# Altium schematic sheet MMF2.SchDoc
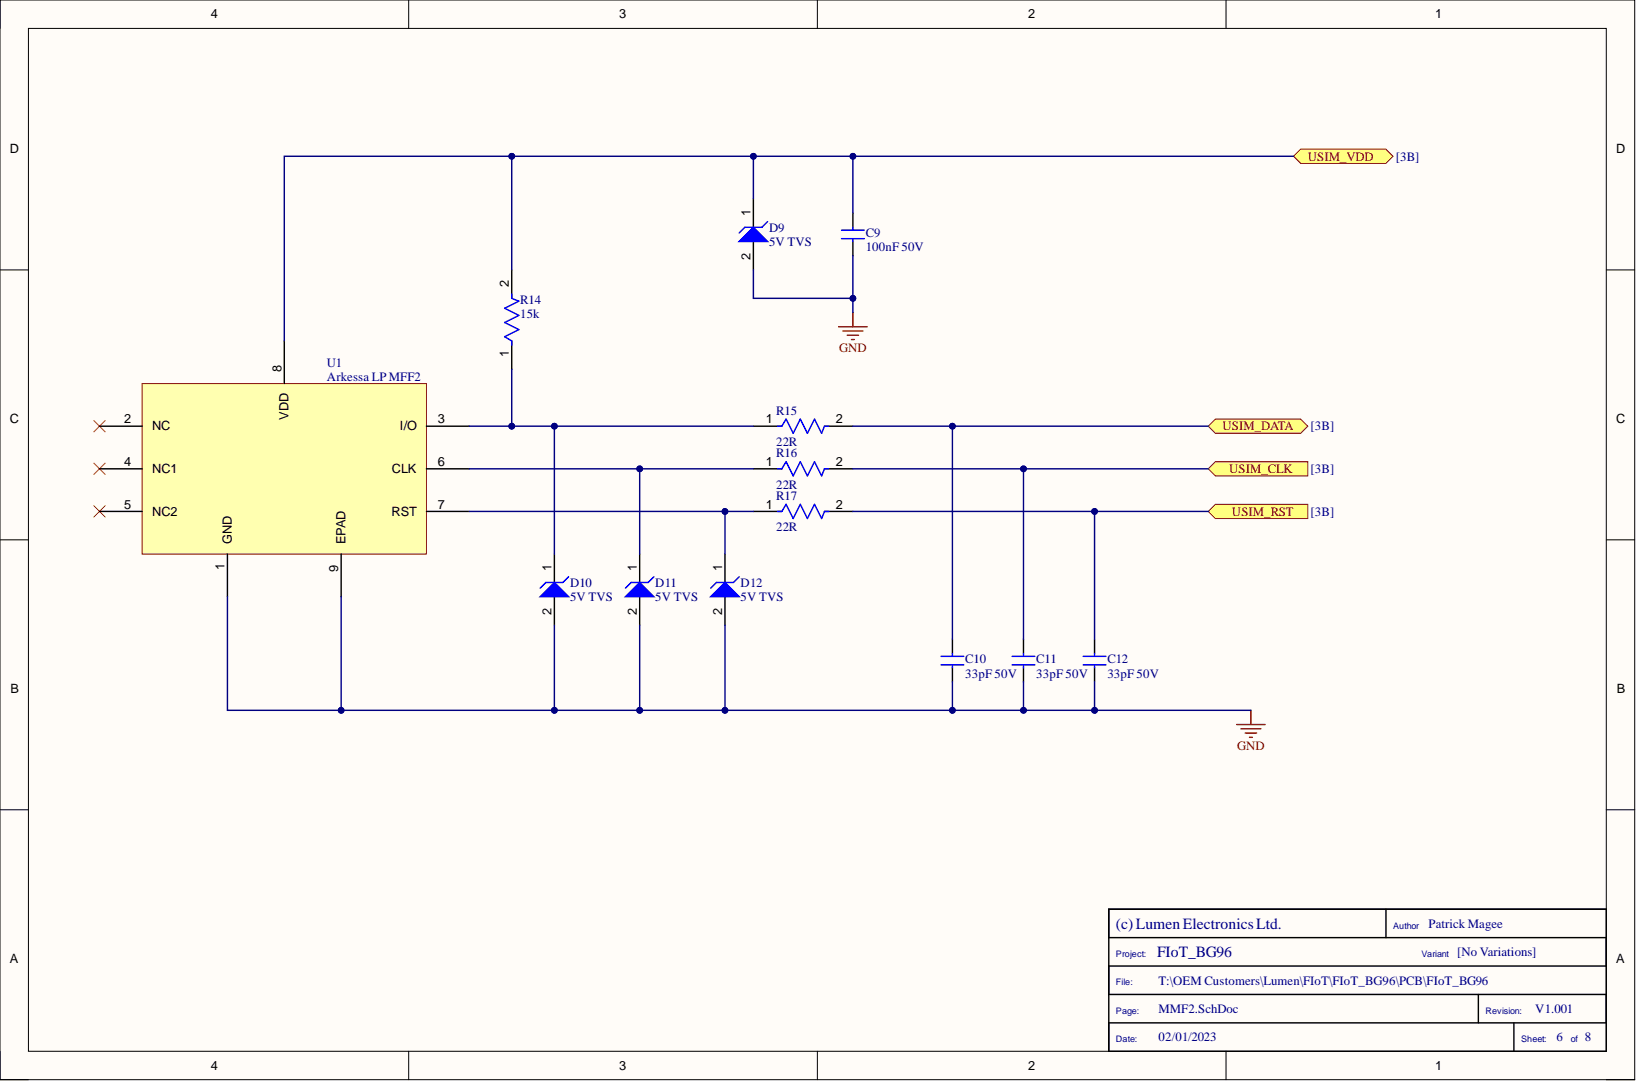
[diagram p0: MMF2.SchDoc]

## Parts
C9  value 100nF 50V  [CAPC1005X06N]  (hidden comment: CL05B104KB54PNC)
C10  value 33pF 50V  [CAPC1005X06N]  (hidden comment: GJM1555C1H330GB01D)
C11  value 33pF 50V  [CAPC1005X06N]  (hidden comment: GJM1555C1H330GB01D)
C12  value 33pF 50V  [CAPC1005X06N]  (hidden comment: GJM1555C1H330GB01D)
D9  value 5V TVS  [ONSC-SOD-923-2-514AA-01_V]  (hidden comment: ESD9L5.0ST5G)
D10  value 5V TVS  [ONSC-SOD-923-2-514AA-01_V]  (hidden comment: ESD9L5.0ST5G)
D11  value 5V TVS  [ONSC-SOD-923-2-514AA-01_V]  (hidden comment: ESD9L5.0ST5G)
D12  value 5V TVS  [ONSC-SOD-923-2-514AA-01_V]  (hidden comment: ESD9L5.0ST5G)
R14  Resistance: 15k  [FP-CRCW0402-e3-MFG]  (hidden comment: 0402WGF1502TCE0402WGF1002TCE)
R15  Resistance: 22R  [FP-CRCW0402-e3-MFG]  (hidden comment: 0402WGF220JTCE)
R16  Resistance: 22R  [FP-CRCW0402-e3-MFG]  (hidden comment: 0402WGF220JTCE)
R17  Resistance: 22R  [FP-CRCW0402-e3-MFG]  (hidden comment: 0402WGF220JTCE)
U1  Arkessa LP MFF2  MFG Name: Arkessa  [SON127P600X500X100-9N-D]

## Ports
USIM_CLK, USIM_DATA, USIM_RST, USIM_VDD

## Nets
GND: C9.1 C10.1 C11.1 C12.1 D9.2 D10.2 D11.2 D12.2 U1.1(GND) U1.9(EPAD)
USIM_CLK: C11.2 R16.2 ; port USIM_CLK
USIM_DATA: C10.2 R15.2 ; port USIM_DATA
USIM_RST: C12.2 R17.2 ; port USIM_RST
USIM_VDD: C9.2 D9.1 R14.2 U1.8(VDD) ; port USIM_VDD
NetD10_1: D10.1 R14.1 R15.1 U1.3(I/O)
NetD11_1: D11.1 R16.1 U1.6(CLK)
NetD12_1: D12.1 R17.1 U1.7(RST)

Not connected: U1 2(NC) 4(NC1) 5(NC2)

## Library data of the parts
C9 = CL05B104KB54PNC: 100nF 50V X7R ±10% 0402 MLCC; Manufacturer: TDK; Manufacturer Part Number: C1005C0G1H060C; MFG Part Number: GRM1555C1H6R0CA01D; MFG Name: Murata; Component Kind: Standard; JLC Footprint: CAP-SMD; JLCPCB Part #: C162118; Library Name: FIoT_BG96.SCHLIB; Part Number: GRM1555C1H6R0CA01D; Supplier 1: Digi-Key; Supplier 2: Mouser; Supplier Part Number 1: 1276-CL05B104KB54PNCCT-ND; Supplier Part Number 2: 187-CL05B104KB54PNC
C10-C12 = GJM1555C1H330GB01D: 33pF 50V C0G ±2% 0402 MLCC; Manufacturer: TDK; Manufacturer Part Number: C1005C0G1H060C; MFG Part Number: GRM1555C1H6R0CA01D; MFG Name: Murata; Component Kind: Standard; JLC Footprint: CAP-SMD; JLCPCB Part #: C162118; Library Name: FIoT_BG96.SCHLIB; Part Number: GRM1555C1H6R0CA01D; Supplier 1: Farnell; Supplier 2: Digi-Key; Supplier 3: Mouser; Supplier Part Number 1: 3784396; Supplier Part Number 2: 490-GJM1555C1H330GB01DCT-ND; Supplier Part Number 3: 81-GJM1555C1H330GB1D
  C10: Value: 33pF 50V
D9-D12 = ESD9L5.0ST5G: Transient Voltage Suppressor, ESD Protection Diode with Ultra-Low Capacitance, 2-Pin SOD-923, Pb-Free, Tape and Reel; Manufacturer: ON Semiconductor; C Max (pF): 0.9; Direction: Unidirectional; IR Max (uA): 1; MFG Name: onsemi; V(BR) Min (V): 5.4; VRWM Max (V): 5; Component Kind: Standard; ComponentLink1Description: Manufacturer URL; ComponentLink1URL: http://www.onsemi.com/; ComponentLink2Description: Datasheet; ComponentLink2URL: http://www.onsemi.cn/pub_link/Collateral/ESD9L-D.PDF; ComponentLink3Description: Package Specification; ComponentLink3URL: http://www.onsemi.com/pub_link/Collateral/514AB.PDF; DatasheetVersion: Rev. 5; JLC Footprint: SOD-923; JLCPCB Part #: C82326; Library Name: FIoT_BG96.SCHLIB; Manufacturer Part Number: ESD9L5.0ST5G; Manufacturer_Name: On Semiconductor; Manufacturer_Part_Number: ESD9L5.0ST5G; MFG Part Number: ESD9L5.0ST5G; Mounting Technology: Surface Mount; Number of Lines: 1; PackageDescription: 2-Pin SOD, Body 0.8 x 0.6 mm; PackageReference: SOD-923-2-514AB-01; PackageVersion: Rev. C, 03/2011; Packing: Tape and Reel; PartNumber: ESD9L5.0ST5G; RoHS: Pb-Free; Supplier 1: Digi-Key; Supplier 2: Mouser; Supplier 3: LCSC; Supplier Part Number 1: ESD9L5.0ST5GOSCT-ND; Supplier Part Number 2: 863-ESD9L5.0ST5G; Supplier Part Number 3: C82326
R14 = 0402WGF1502TCE: Res Thick Film 0402 15K Ohm 1% 0.063W; Manufacturer: Vishay Dale; Manufacturer Part Number: CRCW04021K00FKED; Max Power Dissipation: 63mW; Temperature Coefficient: 100ppm/°C; Voltage Rating: 50V; Case Code (Imperial): 0402; Case Code (Metric): 1005; Case/Package: 0402; Component Kind: Standard; Composition: Thick Film; Contact Plating: Tin; Depth: 0.5mm; Features: Automotive AEC-Q200; Height: 0.35mm; JLC Footprint: R0402; JLCPCB Part #: Not available with JLC; Lead Free: Lead Free; Length: 1mm; Library Name: FIoT_BG96.SCHLIB; Max Operating Temperature: 155°C; MFG Name: Vishay Dale; MFG Part Number: CRCW04021K00FKED; Military Standard: Not; Min Operating Temperature: -55°C; Mount: Surface Mount; Number of Pins: 2; Number of Terminations: 2; Package Quantity: 10; Packaging: Tape and Reel; Power Rating: 63mW; Radiation Hardening: No; Supplier 1: Farnell; Supplier 2: Mouser; Supplier 3: LCSC; Supplier Part Number 1: 1127145; Supplier Part Number 2: 303-0402WGF1502TCE; Supplier Part Number 3: C25756; Termination: SMD/SMT; Tolerance: 1%; Voltage Rating (DC): 50V; Weight: 2.3E-05oz; Width: 0.5mm
R15-R17 = 0402WGF220JTCE: Res Thick Film 0402 22R Ohm 1% 0.063W; Manufacturer: Vishay Dale; Manufacturer Part Number: CRCW04021K00FKED; Max Power Dissipation: 63mW; Temperature Coefficient: 100ppm/°C; Voltage Rating: 50V; Case Code (Imperial): 0402; Case Code (Metric): 1005; Case/Package: 0402; Component Kind: Standard; Composition: Thick Film; Contact Plating: Tin; Depth: 0.5mm; Features: Automotive AEC-Q200; Height: 0.35mm; JLC Footprint: R0402; JLCPCB Part #: Not available with JLC; Lead Free: Lead Free; Length: 1mm; Library Name: FIoT_BG96.SCHLIB; Max Operating Temperature: 155°C; MFG Name: Vishay Dale; MFG Part Number: CRCW04021K00FKED; Military Standard: Not; Min Operating Temperature: -55°C; Mount: Surface Mount; Number of Pins: 2; Number of Terminations: 2; Package Quantity: 10; Packaging: Tape and Reel; Power Rating: 63mW; Radiation Hardening: No; Supplier 1: Mouser; Supplier Part Number 1: 303-0402WGF220JTCE; Termination: SMD/SMT; Tolerance: 1%; Voltage Rating (DC): 50V; Weight: 2.3E-05oz; Width: 0.5mm
U1 = Arkessa LP MFF2: ESIM; Component Kind: Standard; JLC Footprint: Not available with JLC; JLCPCB Part #: Not available with JLC; Library Name: FIoT_BG96.SCHLIB; MFG Part Number: Arkessa LP MFF2
